# Altium schematic sheet LuxLogger_V2_sensors.SchDoc
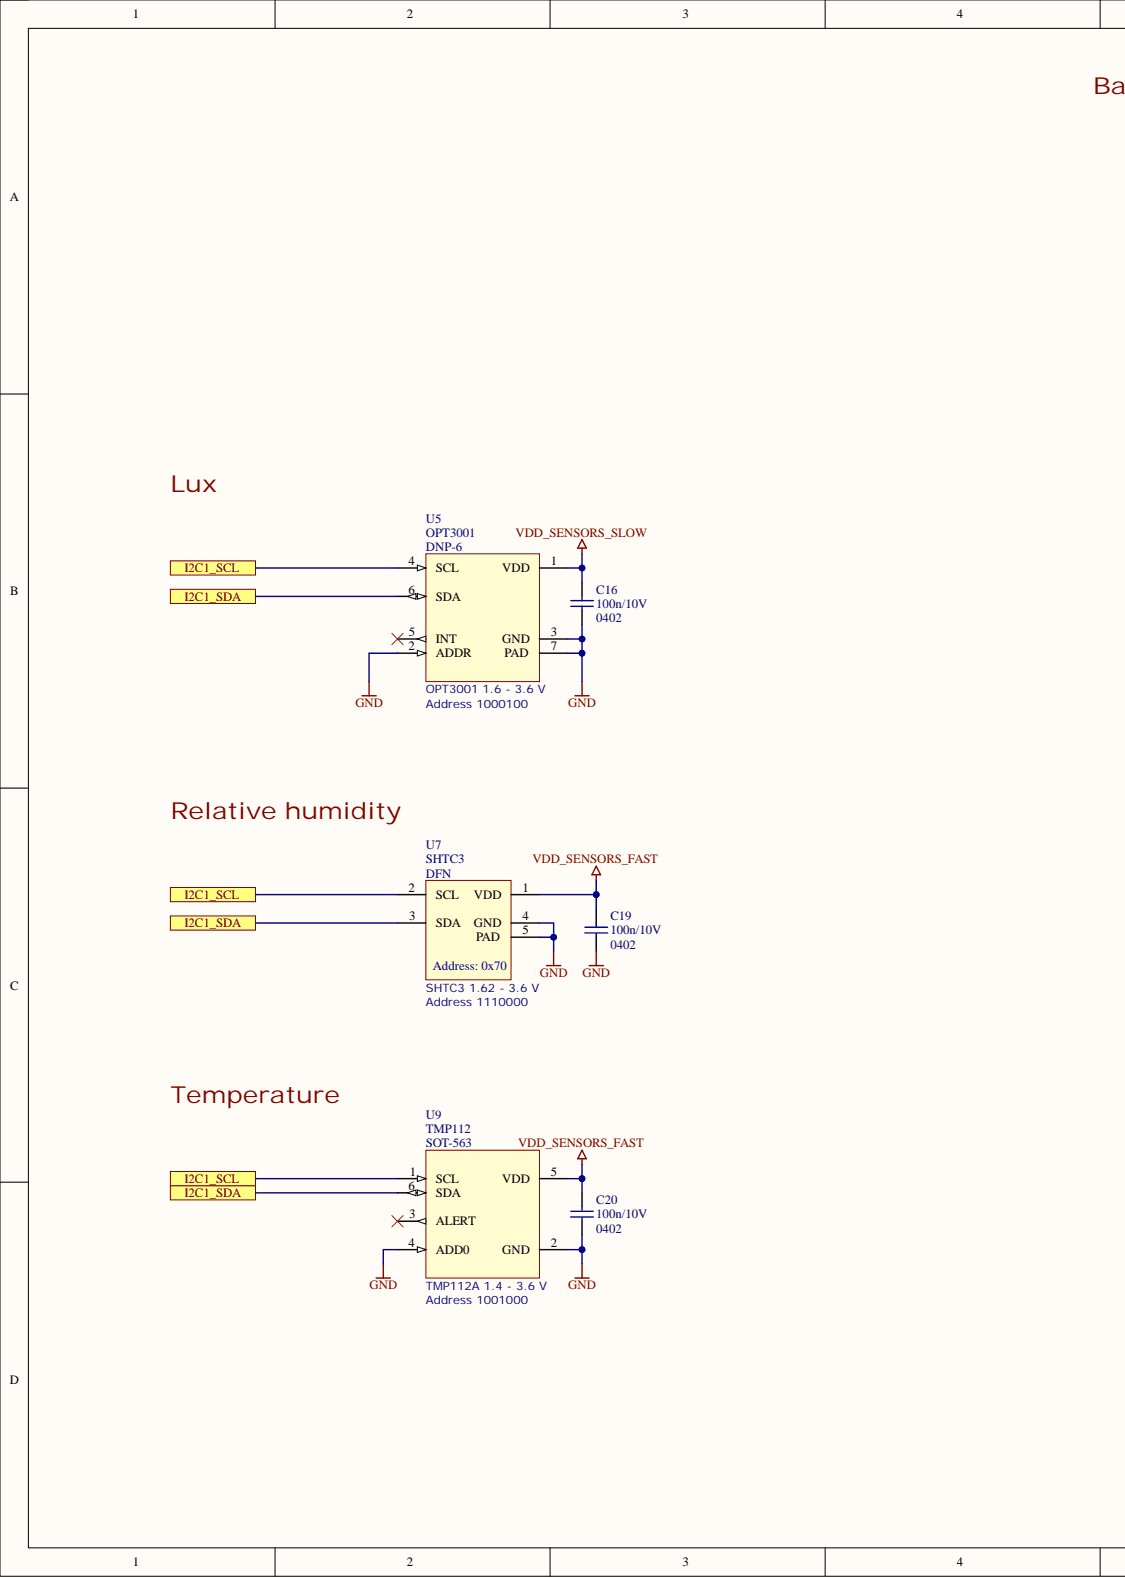
[diagram p0: LuxLogger_V2_sensors.SchDoc, left]
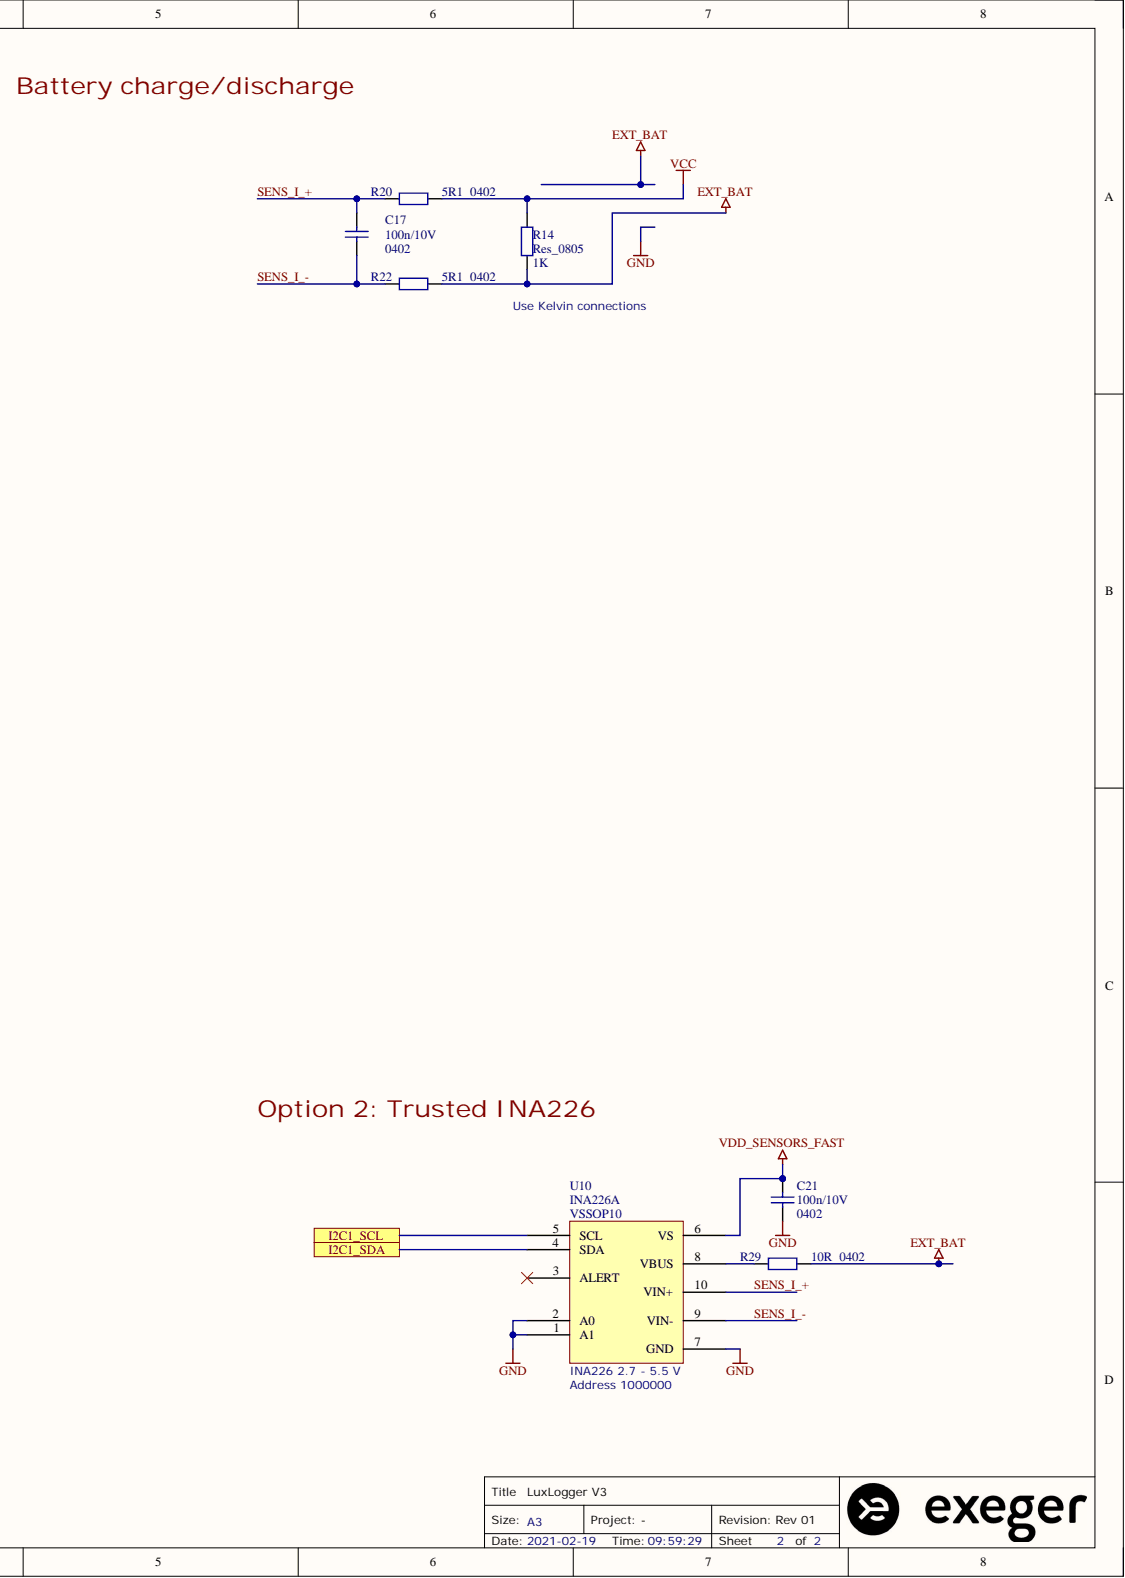
[diagram p1: LuxLogger_V2_sensors.SchDoc, right]

## Parts
C16  Package: 0402  Specification: 100n/10V  [CAP0402]
C17  Package: 0402  Specification: 100n/10V  [CAP0402]
C19  Package: 0402  Specification: 100n/10V  [CAP0402]
C20  Package: 0402  Specification: 100n/10V  [CAP0402]
C21  Package: 0402  Specification: 100n/10V  [CAP0402]
R14  Res_0805  value 1K  [0805]
R20  Package: 0402  Specification: 5R1  [RES0402]
R22  Package: 0402  Specification: 5R1  [RES0402]
R29  Package: 0402  Specification: 10R  [RES0402]
U5  Package: DNP-6  [OPT3001]
U7  Package: DFN  [SHTC3]
U9  Package: SOT-563  Specification: TMP112  [SOTFL50P160X60-6N]
U10  Package: VSSOP10  Specification: INA226A  [VSSOP-10]

## Ports
I2C1_SCL, I2C1_SDA

## Nets
EXT_BAT: R14.1 R22.1 R29.1
GND: C16.1 C19.1 C20.1 C21.1 U5.2(ADDR) U5.3(GND) U5.7(PAD) U7.4(GND) U7.5(PAD) U9.2(GND) U9.4(ADD0) U10.1(A1) U10.2(A0) U10.7(GND)
VCC: R14.2 R20.1
VDD_SENSORS_FAST: C19.2 C20.2 C21.2 U7.1(VDD) U9.5(VDD) U10.6(VS)
VDD_SENSORS_SLOW: C16.2 U5.1(VDD)
I2C1_SCL: U5.4(SCL) U7.2(SCL) U9.1(SCL) U10.5(SCL) ; port I2C1_SCL
I2C1_SDA: U5.6(SDA) U7.3(SDA) U9.6(SDA) U10.4(SDA) ; port I2C1_SDA
SENS_I_+: C17.2 R20.2 U10.10(VIN+)
SENS_I_-: C17.1 R22.2 U10.9(VIN-)
NetR29_2: R29.2 U10.8(VBUS)

Not connected: U5 5(INT); U9 3(ALERT); U10 3(ALERT)

## Library data of the parts
C16 C17 C19-C21 = Cap: Capacitor; Component Kind: Standard; Library Name: Miscellaneous Devices.IntLib
R14 = Res_0805: Resistor; Component Kind: Standard; LatestRevisionDate: 17-Jul-2002; LatestRevisionNote: Re-released for DXP Platform.; Library Name: Schematic_Components.SchLib; PackageDescription: Axial Device, Thru-Hole; 2 Leads; 0.4 in Pin Spacing; PackageReference: AXIAL-0.4; Published: 8-Jun-2000; Signal Integrity: Res; Simulation: RESISTOR; Publisher: Altium Limited
R20 R22 R29 = Res2: Resistor; Component Kind: Standard; Library Name: Miscellaneous Devices.IntLib
U5 = OPT3001: Lux sensor; Manufacturer: TI; Manufacturer PN: OPT3001DNP; Component Kind: Standard; Specification: OPT3001; Library Name: Exeger_schematic_library.SchLib
U7 = SHTC3: SHTC3 RH sensor; Manufacturer: SENSIRION; Component Kind: Standard; Manufacturer PN: SHTC3; Specification: SHTC3; Library Name: Exeger_schematic_library.SchLib
U9 = TMP112A: Temp_Sensor; Manufacturer: TI; Manufacturer PN: TMP112AIDR; Component Kind: Standard; Library Name: Exeger_schematic_library.SchLib
U10 = (no symbol name): Current and power monitor; Manufacturer: TI; Manufacturer PN: INA226AIDGS; Component Kind: Standard; Library Name: Exeger_schematic_library.SchLib
